# Altium schematic sheet sdrtckart.SchDoc
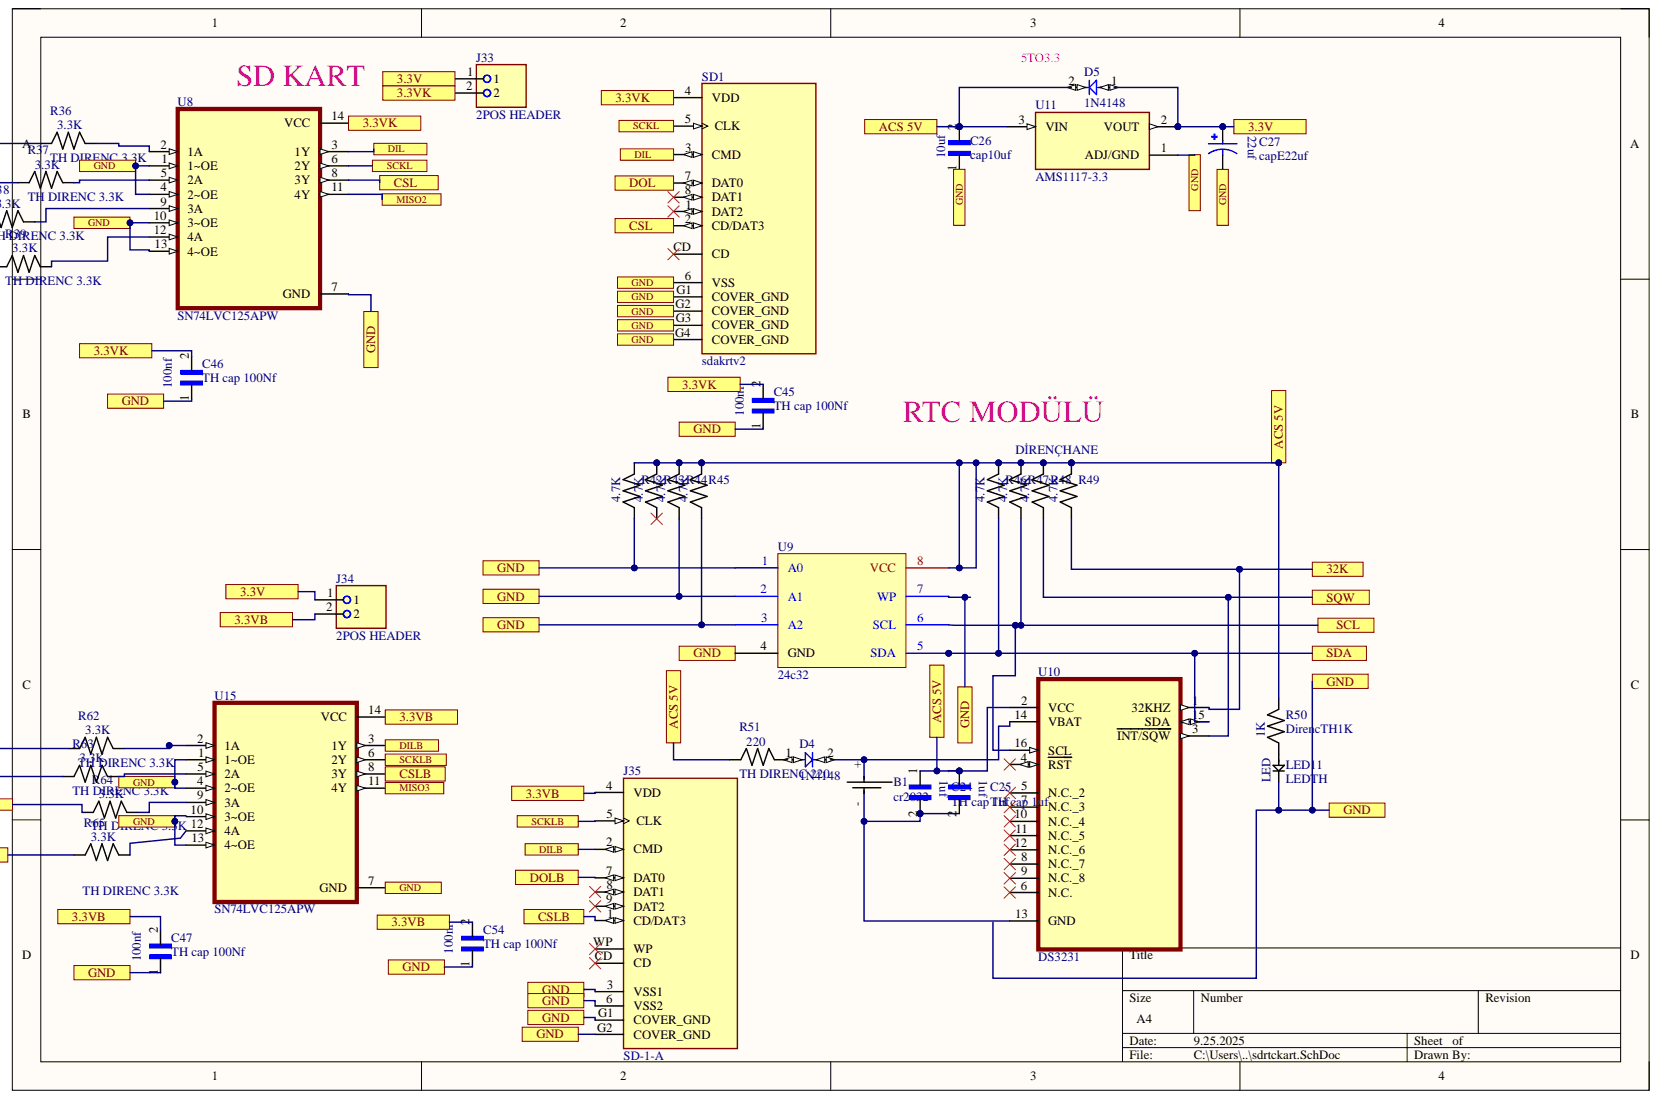
[diagram p0: sdrtckart.SchDoc]

## Parts
B1  cr2032  [vertical battery holder]
C24  TH cap 1uf  [Kapkucuk]
C25  TH cap 1uf  [Kapkucuk]
C26  cap10uf  [1206cap]
C27  capE22uf  [4x7 CAP]
C45  TH cap 100Nf  [Kapkucuk]
C46  TH cap 100Nf  [Kapkucuk]
C47  TH cap 100Nf  [Kapkucuk]
C54  TH cap 100Nf  [Kapkucuk]
D4  1N4148  [1N4148]
D5  1N4148  [1N4148]
J33  2POS HEADER  [1X2 HEADER]
J34  2POS HEADER  [1X2 HEADER]
J35  SD-1-A  [CUI_SD-1-A]
LED11  LEDTH  [led3mm]
R36  TH DIRENC 3.3K  [resistor TH]
R37  TH DIRENC 3.3K  [resistor TH]
R38  TH DIRENC 3.3K  [resistor TH]
R39  TH DIRENC 3.3K  [resistor TH]
R42  TH Direnç 4.7K  [resistor TH]  (value not drawn)
R43  TH Direnç 4.7K  [resistor TH]  (value not drawn)
R44  TH Direnç 4.7K  [resistor TH]  (value not drawn)
R45  TH Direnç 4.7K  [resistor TH]  (value not drawn)
R46  TH Direnç 4.7K  [resistor TH]  (value not drawn)
R47  TH Direnç 4.7K  [resistor TH]  (value not drawn)
R48  TH Direnç 4.7K  [resistor TH]  (value not drawn)
R49  TH Direnç 4.7K  [resistor TH]  (value not drawn)
R50  DirencTH1K  [resistor TH]
R51  TH DIRENC 220  [resistor TH]
R62  TH DIRENC 3.3K  [resistor TH]
R63  TH DIRENC 3.3K  [resistor TH]
R64  TH DIRENC 3.3K  [resistor TH]
R65  TH DIRENC 3.3K  [resistor TH]
SD1  sdakrtv2  [sdkartv2]
U8  SN74LVC125APW  [SOP65P640X120-14N]
U9  24c32  [dip8]
U10  DS3231  [soic16]
U11  AMS1117-3.3  [v3.3 footprint]
U15  SN74LVC125APW  [SOP65P640X120-14N]

## Ports
3.3V, 3.3VB, 3.3VK, 32K, ACS 5V, CSL, CSLB, DIL, DILB, DOL, DOLB, GND, MISO2, MISO3, MOSI2, MOSI3, PB1/2, PB1/3, SCK2, SCK3, SCKL, SCKLB, SCL, SDA, SQW

## Nets
3.3V: C27.P D5.1 J33.1 J34.1 U11.2 ; port 3.3V
3.3VB: C47.2 C54.2 J34.2 J35.4(VDD) U15.14(VCC) ; port 3.3VB
3.3VK: C45.2 C46.2 J33.2 SD1.4(VDD) U8.14(VCC) ; port 3.3VK
32K: R49.0 U10.1(32KHZ) ; port 32K
ACS 5V: C24.1 C25.1 C26.2 D5.2 R42.1 R43.1 R44.1 R45.1 R46.1 R47.1 R48.1 R49.1 R50.1 R51.0 U9.8(VCC) U10.2(VCC) U11.3 ; port ACS 5V
CSL: SD1.2(CD/DAT3) U8.8(3Y) ; port CSL
CSLB: J35.1(CD/DAT3) U15.8(3Y) ; port CSLB
DIL: SD1.3(CMD) U8.3(1Y) ; port DIL
DILB: J35.2(CMD) U15.3(1Y) ; port DILB
DOL: R39.0 SD1.7(DAT0) ; port DOL
DOLB: J35.7(DAT0) R65.0 ; port DOLB
GND: C26.1 C27.N C45.1 C46.1 C47.1 C54.1 J35.3(VSS1) J35.6(VSS2) J35.G1(COVER_GND) J35.G2(COVER_GND) LED11.1 R42.0 R44.0 R45.0 SD1.6(VSS) SD1.G1(COVER_GND) SD1.G2(COVER_GND) SD1.G3(COVER_GND) SD1.G4(COVER_GND) U8.1(1~OE) U8.4(2~OE) U8.7(GND) U8.10(3~OE) U8.13(4~OE) U9.1(A0) U9.2(A1) U9.3(A2) U9.4(GND) U9.7(WP) U11.1 U15.1(1~OE) U15.4(2~OE) U15.7(GND) U15.13(4~OE) ; port GND
MISO2: U8.11(4Y) ; port MISO2
MISO3: U15.11(4Y) ; port MISO3
SCKL: SD1.5(CLK) U8.6(2Y) ; port SCKL
SCKLB: J35.5(CLK) U15.6(2Y) ; port SCKLB
SCL: R47.0 U9.6(SCL) U10.16(SCL) ; port SCL
SDA: R46.0 U9.5(SDA) U10.15(SDA) ; port SDA
SQW: R48.0 U10.3(INT/SQW) ; port SQW
NetB1_+: B1.+ D4.2 U10.14(VBAT)
NetB1_-: B1.- C24.2 C25.2 U10.13(GND)
NetD4_1: D4.1 R51.1
NetLED11_0: LED11.0 R50.0
NetR36_0: R36.0
NetR36_1: R36.1 U8.2(1A)
NetR37_0: R37.0
NetR37_1: R37.1 U8.5(2A)
NetR38_0: R38.0
NetR38_1: R38.1 U8.9(3A)
NetR39_1: R39.1 U8.12(4A)
NetR62_0: R62.0
NetR62_1: R62.1 U15.2(1A)
NetR63_0: R63.0
NetR63_1: R63.1 U15.5(2A)
NetR64_0: R64.0
NetR64_1: R64.1 U15.9(3A)
NetR65_1: R65.1 U15.12(4A)

Not connected: J35 8(DAT1) 9(DAT2) CD WP; R43 0; SD1 1(DAT2) 8(DAT1) CD; U10 4(RST) 5(N.C._2) 6(N.C.) 7(N.C._3) 8(N.C._7) 9(N.C._8) 10(N.C._4) 11(N.C._5) 12(N.C._6); U15 10(3~OE)

## Library data of the parts
J35 = SD-1-A: SD Card Connector, 11 Positions, Connector and Ejector, Push In, Auto Eject Out, Surface Mount, 2.83 mm Height Above Board, Gold Flash; MF: Same Sky; Check_prices: https://www.snapeda.com/parts/SD-1-A/Same+Sky/view-part/?ref=eda; Component Kind: Standard; Library Name: Copy of Parts.SchLib; MP: SD-1-A; Package: None; SnapEDA_Link: https://www.snapeda.com/parts/SD-1-A/Same+Sky/view-part/?ref=snap
SD1 = sdakrtv2: Micro SD Card Connector, 9 Positions, Connector and Ejector, Push In, Auto Eject Out, Surface Mount, 1.8 mm Height Above Board, Gold Flash; MF: Same Sky; MP: MSD-1-A; Check_prices: https://www.snapeda.com/parts/MSD-1-A/Same+Sky/view-part/?ref=eda; Component Kind: Standard; Library Name: Copy of Parts.SchLib; Package: None; SnapEDA_Link: https://www.snapeda.com/parts/MSD-1-A/Same+Sky/view-part/?ref=snap
U8 U15 = SN74LVC125APW: 4-ch, 1.65-V to 3.6-V buffers with 3-state outputs; MF: Texas Instruments; Check_prices: https://www.snapeda.com/parts/SN74LVC125APW/Texas+Instruments/view-part/?ref=eda; Component Kind: Standard; Library Name: Copy of Parts.SchLib; MP: SN74LVC125APW; Package: TSSOP-14 Texas Instruments; SnapEDA_Link: https://www.snapeda.com/parts/SN74LVC125APW/Texas+Instruments/view-part/?ref=snap
U11 = AMS1117-3.3: Linear Voltage Regulator IC Positive Fixed 1 Output 1A SOT-223-3L; MF: UMW; Check_prices: https://www.snapeda.com/parts/AMS1117-3.3/UMW/view-part/?ref=eda; Component Kind: Standard; Library Name: Copy of Parts.SchLib; MP: AMS1117-3.3; Package: SOT-223-3L UMW; SnapEDA_Link: https://www.snapeda.com/parts/AMS1117-3.3/UMW/view-part/?ref=snap
B1 = cr2032: Component Kind: Standard; Library Name: Copy of Parts.SchLib
C24 C25 = TH cap 1uf: Component Kind: Standard; Library Name: Copy of Parts.SchLib
C26 = cap10uf: Component Kind: Standard; Library Name: Copy of Parts.SchLib
C27 = capE22uf: Component Kind: Standard; Library Name: Copy of Parts.SchLib
C45-C47 C54 = TH cap 100Nf: Component Kind: Standard; Library Name: Copy of Parts.SchLib
D4 D5 = 1N4148: Component Kind: Standard; Library Name: Copy of Parts.SchLib
J33 J34 = 2POS HEADER: Component Kind: Standard; Library Name: Copy of Parts.SchLib
LED11 = LEDTH: Component Kind: Standard; Library Name: Copy of Parts.SchLib
R36-R39 R62-R65 = TH DIRENC 3.3K: Component Kind: Standard; Library Name: Copy of Parts.SchLib
R42-R49 = TH Direnç 4.7K: Component Kind: Standard; Library Name: Copy of Parts.SchLib
R50 = DirencTH1K: Component Kind: Standard; Library Name: Copy of Parts.SchLib
R51 = TH DIRENC 220: Component Kind: Standard; Library Name: Copy of Parts.SchLib
U9 = 24c32: Component Kind: Standard; Library Name: Copy of Parts.SchLib
U10 = DS3231: Component Kind: Standard; Library Name: Copy of Parts.SchLib
